# Altium schematic sheet Schematic.SchDoc(Schematic)
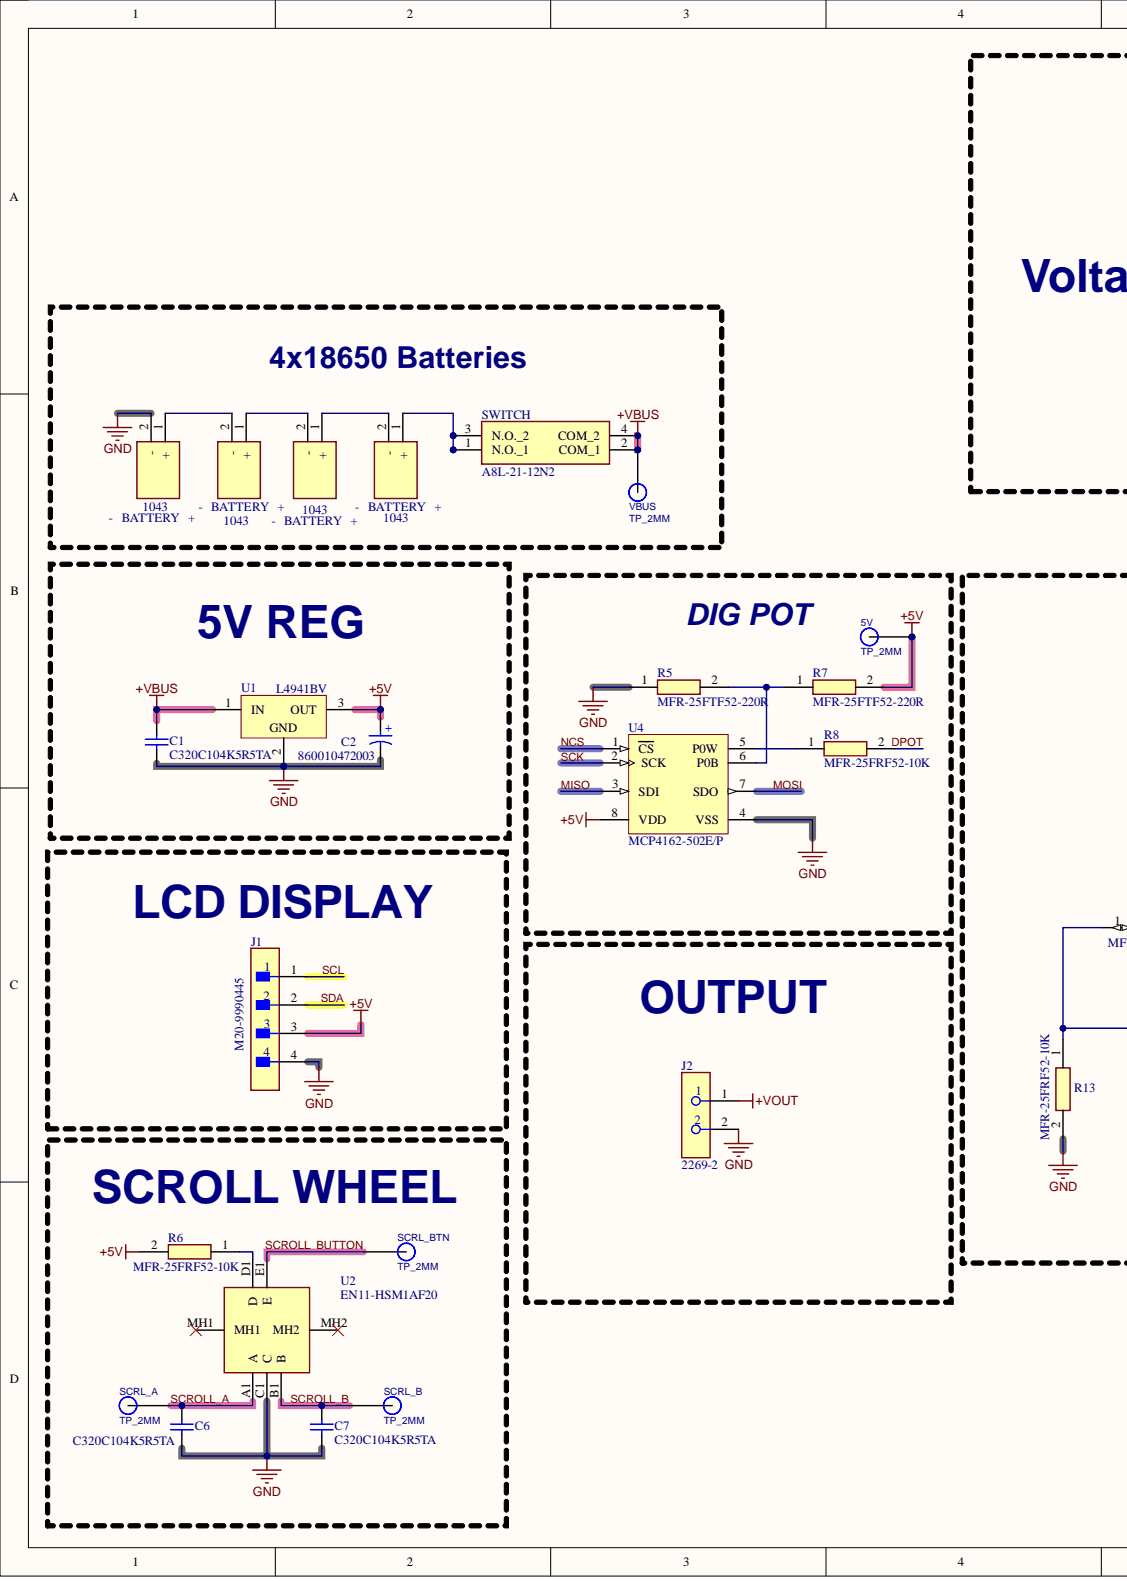
[diagram p0: Schematic.SchDoc, left]
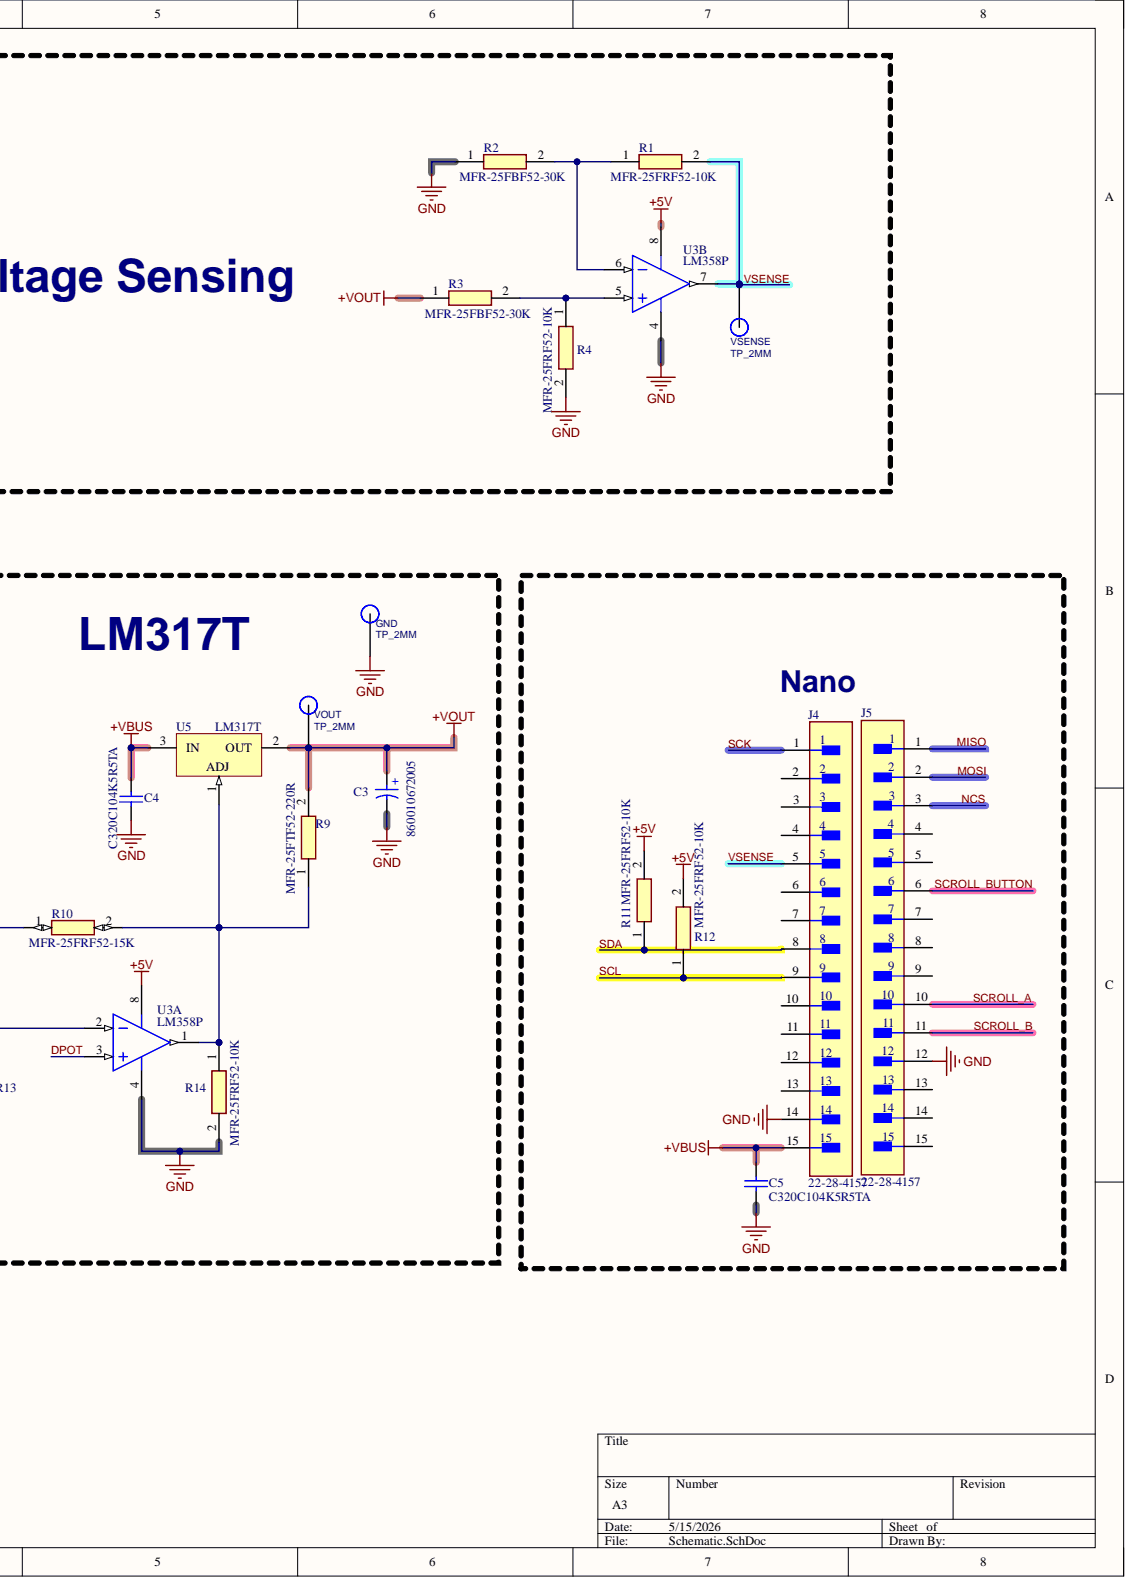
[diagram p1: Schematic.SchDoc, right]

## Parts
5V  TP_2MM
-_BATTERY_+  1043
-_BATTERY_+  1043
-_BATTERY_+  1043
-_BATTERY_+  1043
C1  C320C104K5R5TA
C2  860010472003
C3  860010672005
C4  C320C104K5R5TA
C5  C320C104K5R5TA
C6  C320C104K5R5TA
C7  C320C104K5R5TA
GND  TP_2MM
J1  M20-9990445
J2  2269-2
J4  22-28-4157
J5  22-28-4157
R1  MFR-25FRF52-10K
R2  MFR-25FBF52-30K
R3  MFR-25FBF52-30K
R4  MFR-25FRF52-10K
R5  MFR-25FTF52-220R
R6  MFR-25FRF52-10K
R7  MFR-25FTF52-220R
R8  MFR-25FRF52-10K
R9  MFR-25FTF52-220R
R10  MFR-25FRF52-15K
R11  MFR-25FRF52-10K
R12  MFR-25FRF52-10K
R13  MFR-25FRF52-10K
R14  MFR-25FRF52-10K
SCRL_A  TP_2MM
SCRL_B  TP_2MM
SCRL_BTN  TP_2MM
SWITCH  A8L-21-12N2
U1  L4941BV
U2  EN11-HSM1AF20
U3  LM358P  (parts A,B)
U4  MCP4162-502E/P
U5  LM317T
VBUS  TP_2MM
VOUT  TP_2MM
VSENSE  TP_2MM

## Nets
+5V: 5V.TP C2.1 J1.3 R6.2 R7.2 R11.2 R12.2 U1.3 U3.8 U4.8(VDD)
+VBUS: C1.2 C4.2 C5.2 J4.15 SWITCH.2(COM_1) SWITCH.4(COM_2) U1.1 U5.3 VBUS.TP
+VOUT: C3.1 J2.1 R3.1 R9.2 U5.2 VOUT.TP
GND: -_BATTERY_+.2 C1.1 C2.2 C3.2 C4.1 C5.1 C6.1 C7.1 GND.TP J1.4 J2.2 J4.14 J5.12 R2.1 R4.2 R5.1 R13.2 R14.2 U1.2 U2.C1(C) U3.4 U4.4(VSS)
DPOT: R8.2 U3.3
MISO: J5.1 U4.3(SDI)
MOSI: J5.2 U4.7(SDO)
NCS: J5.3 U4.1(CS)
SCK: J4.1 U4.2(SCK)
SCL: J1.1 J4.9 R12.1
SCROLL_A: C6.2 J5.10 SCRL_A.TP U2.A1(A)
SCROLL_B: C7.2 J5.11 SCRL_B.TP U2.B1(B)
SCROLL_BUTTON: J5.6 SCRL_BTN.TP U2.E1(E)
SDA: J1.2 J4.8 R11.1
VSENSE: J4.5 R1.2 U3.7 VSENSE.TP
Net-   BATTERY   +_1: -_BATTERY_+.1 -_BATTERY_+.2 SWITCH.1(N.O._1) SWITCH.3(N.O._2)
NetR1_1: R1.1 R2.2 U3.6
NetR3_2: R3.2 R4.1 U3.5
NetR5_2: R5.2 R7.1 U4.6(P0B)
NetR6_1: R6.1 U2.D1(D)
NetR8_1: R8.1 U4.5(P0W)
NetR9_1: R9.1 R10.2 R14.1 U3.1 U5.1
NetR10_1: R10.1 R13.1 U3.2

Not connected: J4 2 3 4 6 7 10 11 12 13; J5 4 5 7 8 9 13 14 15; U2 MH1 MH2

## Library data of the parts
-_BATTERY_+ -_BATTERY_+ -_BATTERY_+ -_BATTERY_+ = 1043: Undefined or Miscellaneous; Manufacturer_Name: Keystone Electronics; Arrow Part Number: 1043; Arrow Price/Stock: https://www.arrow.com/en/products/1043/keystone-electronics.html?utm_currency=USD&region=europe; Component Kind: Standard; Datasheet Link: http://www.keyelco.com/product-pdf.cfm?p=919; Manufacturer_Part_Number: 1043; Mouser Part Number: 534-1043; Mouser Price/Stock: https://www.mouser.co.uk/ProductDetail/Keystone-Electronics/1043?qs=%2F7TOpeL5Mz6j%2FnxeOA1rsg%3D%3D
C1 C4-C7 = CMP-1664-00004-1: Radial Leaded Multilayer Ceramic Capacitor, 0.1 uF, +/- 10%, 50 V, -55 to 125 degC, 2-Pin THD, RoHS, Tape and Reel; Case\Package: SOT-23-5; Dropout Voltage: 260 mV; Max Input Voltage: 8 V; Max Power Dissipation: 250 mW; Output Current: 150 mA; Output Voltage: 5 V; Outputs: 1; Component Kind: Standard; ComponentLink1Description: Manufacturer URL; ComponentLink1URL: http://www.kemet.com/; ComponentLink2Description: Datasheet; ComponentLink2URL: http://www.kemet.com/docfinder?Partnumber=C320C104K5R5TA; DatasheetVersion: Dec-15; Height: 1.3 mm; Length: 3 mm; Library Name: Altium Content Vault; Max Operating Temperature: 85 degC; Min Operating Temperature: -40 degC; Mount: Surface Mount; Package Quantity: 3000; PackageDescription: 2-Pin Through Hole Device, Body 5.08 x 3.18 mm, Pitch 2.54 mm; PackageReference: CAPR51-254-508X318X736; Packaging: Tape and Reel; Pins: 5; Radiation Hardening: No; RoHSCompliant: true; Width: 1.8 mm
C2 = CMP-207834-000017-3: WCAP-ATG8 Aluminum Electrolytic Capacitors; Capacitance: 22µF; Dissipation Factor: 14%; Leakage Current: 5.5µA; Life (Hours): 2000hours; Material: Aluminium; Pitch: 2mm; Polarity: Polar; Ripple Current: 94mA; Tolerance: 20%; Voltage Rating: 25V; Case/Package: Radial; Component Kind: Standard; Diameter: 5mm; Height: 12.5mm; Height - Seated (Max): 12.4968mm; Lead Pitch: 2.0066mm; Length: 11mm; Library Name: Altium Content Vault; Max Operating Temperature: 85°C; Min Operating Temperature: -40°C; Mount: Through Hole; Packaging: Tape & Box (TB); REACH SVHC: Unknown; RoHS: Compliant; Voltage Rating (DC): 25V
C3 = CMP-03913-54007258-2: Alum. Electrolytic Cap 1UF 50V 5MM Radial WCAP-ATG8 Series Lifetime 2000H +85OC; Capacitance: 1µF; Leakage Current: 3µA; Life (Hours): 2000hours; Material: Aluminium; Pitch: 2mm; Polarity: Polar; Ripple Current: 17mA; Tolerance: 20%; Case/Package: Radial; Component Kind: Standard; Diameter: 5mm; Height: 11mm; Height - Seated (Max): 12.4968mm; Lead Pitch: 2.0066mm; Length: 11mm; Library Name: Altium Content Vault; Max Operating Temperature: 85°C; Min Operating Temperature: -40°C; Mount: Through Hole; Packaging: Tape & Box (TB); REACH SVHC: Unknown; RoHS: Compliant; Voltage Rating: 50V; Voltage Rating (DC): 50V
J1 = CMP-00671-05399696-1: M20-9990445 Conn Unshrouded Header HDR 4 POS 2.54mm Solder ST Thru-Hole Bulk/Loose; Connector Type: Male Pin; Contact Resistance: 25mΩ; Current Rating: 3A; Gender: Male; Insulation Resistance: 10GΩ; Number of Contacts: 4; Voltage Rating (AC): 750V; Case/Package: SIL; Color: Black; Component Kind: Standard; Contact Material: Brass, Bronze, Gold, Nickel; Contact Mating Length: 6.096mm; Contact Plating: Gold; Depth: 2.54mm; Height: 2.54mm; Housing Color: Black; Housing Material: Nylon; Lead Pitch: 2.54mm; Length: 10.16mm; Library Name: Altium Content Vault; Max Current Rating: 3A; Max Operating Temperature: 105°C; Min Operating Temperature: -40°C; Mount: Through Hole; NexarPartId: 5399696; Number of Pins: 4; Number of Positions: 4; Number of Rows: 1; Orientation: Straight; Pitch: 2.54mm; Radiation Hardening: No; REACH SVHC: No SVHC; RoHS: Compliant; Termination: Solder; Voltage Rating (DC): 750V; Weight: 45.359237g; Width: 12.7mm
J2 = CMP-73906-000010-1: CONN DBL BANANA JACK TURRET; Body Material: PC; Current Rating: 15A; Gender: Female; Insulation: Mating End Insulated; Number of Contacts: 2; Voltage Rating: 2.5kV; Voltage Rating (DC): 70V; Color: Red; Component Kind: Standard; Contact Material: Brass; Contact Plating: Tin; Depth: 16mm; Height: 0.375inch; Housing Color: Red; Housing Material: Polycarbonate; Insulation Material: Polycarbonate; Lead Free: Lead Free; Length: 24inch; Library Name: Altium Content Vault; Max Current Rating: 15A; Mount: Panel; Number of Ports: 2; Orientation: Straight; Package Quantity: 5; Packaging: Bulk; REACH SVHC: No SVHC; RoHS Compliant: Yes; Termination: Solder; Weight: 0.033lb; Width: 4inch
J4 J5 = CMP-04776-000945-1: KK 100 HDR ASSY BKWY 15 CKT 30 S; Connector Type: Male Pin; Contact Resistance: 20mR; Current Rating: 4A; Gender: Male; Glow Wire Compliant: Non-compliant; Max Voltage Rating (DC): 250.25kV; Number of Circuits: 15; Pitch: 2.54mm; Voltage Rating: 250V; Color: Black; Component Kind: Standard; Contact Material: Brass; Contact Mating Length: 0.32inch; Contact Plating: Gold; Contact Type: Male Pin; Housing Color: Black; Housing Material: Thermoplastic; Lead Free: Lead Free; Library Name: Altium Content Vault; Max Operating Temperature: 75°C; Min Operating Temperature: 0°C; Mount: Through Hole; Number of Contacts: 15; Number of Positions: 15; Number of Rows: 1; Orientation: Straight; Package Quantity: 1; Packaging: Bulk; Series: KK® 42375; Termination: Solder
R1 R4 R6 R8 R11-R14 = MFR-25FRF52-10K: Resistor; Manufacturer_Name: Yageo Group; Arrow Part Number: MFR-25FRF52-10K; Arrow Price/Stock: https://www.arrow.com/en/products/mfr-25frf52-10k/yageo; Component Kind: Standard; Datasheet Link: https://mentor.componentsearchengine.com/Datasheets/1/MFR-25FRF52-10K.pdf; Manufacturer_Part_Number: MFR-25FRF52-10K; Mouser Part Number: 603-MFR-25FRF5210K; Mouser Price/Stock: https://www.mouser.co.uk/ProductDetail/YAGEO/MFR-25FRF52-10K?qs=5furm%2FeQHzSFNehIjUjbug%3D%3D
R2 R3 = MFR-25FBF52-30K: Resistor; Manufacturer_Name: Yageo Group; Component Kind: Standard; Datasheet Link: https://www.mouser.com/datasheet/2/447/YAGEO_MFR_datasheet_2023v3-3324391.pdf; Manufacturer_Part_Number: MFR-25FBF52-30K
R5 R7 R9 = MFR-25FTF52-220R: Resistor; Manufacturer_Name: Yageo Group; Component Kind: Standard; Datasheet Link: https://www.mouser.de/datasheet/2/447/YAGEO_MFR_datasheet_2023v3-3324391.pdf; Manufacturer_Part_Number: MFR-25FTF52-220R
R10 = MFR-25FRF52-15K: Resistor; Manufacturer_Name: Yageo Group; Arrow Part Number: MFR-25FRF52-15K; Arrow Price/Stock: https://www.arrow.com/en/products/mfr-25frf52-15k/yageo; Component Kind: Standard; Datasheet Link: https://nz.mouser.com/datasheet/2/447/Yageo_LR_MFR_1-1714151.pdf; Manufacturer_Part_Number: MFR-25FRF52-15K; Mouser Part Number: 603-MFR-25FRF5215K; Mouser Price/Stock: https://www.mouser.co.uk/ProductDetail/Yageo/MFR-25FRF52-15K?qs=5furm%2FeQHzTYkY1Zl33S4g%3D%3D
SWITCH = A8L-21-12N2: Switch; Manufacturer_Name: OMRON Electronics; Arrow Part Number: A8L-21-12N2; Arrow Price/Stock: https://www.arrow.com/en/products/a8l-21-12n2/omron?utm_currency=USD&region=nac; Component Kind: Standard; Datasheet Link: https://components.omron.com/us-en/sites/components.omron.com.us/files/datasheet_pdf/A114-E1.pdf; Height: 22.1mm; Library Name: Schematic Library.SchLib; Manufacturer_Part_Number: A8L-21-12N2; Mouser Part Number: 653-A8L-21-12N2; Mouser Price/Stock: https://www.mouser.co.uk/ProductDetail/Omron-Electronics/A8L-21-12N2?qs=wE98CiVmOw76dmZWfHCJ3A%3D%3D
U1 = CMP-0244-00423-1: 1A Very Low Drop Regulator, 3-Pin TO-220; Component Kind: Standard; ComponentLink1Description: Manufacturer URL; ComponentLink1URL: http://www.st.com; ComponentLink2Description: Datasheet; ComponentLink2URL: http://www.st.com/internet/com/TECHNICAL_RESOURCES/TECHNICAL_LITERATURE/DATASHEET/CD00000443.pdf; ComponentLink3Description: Package Specification; ComponentLink3URL: http://www.st.com/internet/com/TECHNICAL_RESOURCES/TECHNICAL_LITERATURE/PACKAGE_INFORMATION/CD00004649.pdf; DatasheetVersion: 15/09/2006; Library Name: Altium Content Vault; PackageDescription: TO, Thru-Hole, Vertical, Heatsink Mounted; 3 In-Line Leads; Pitch 2.54 mm; PackageReference: TO220; PackageVersion: ver3.0
U2 = EN11-HSM1AF20: Undefined or Miscellaneous; Manufacturer_Name: TT Electronics; Component Kind: Standard; Datasheet Link: https://datasheet.datasheetarchive.com/originals/distributors/Datasheets_SAMA/2e239bccdca13b9604c0057335e160d7.pdf; Height: 27.1mm; Manufacturer_Part_Number: EN11-HSM1AF20; Mouser Part Number: 858-EN11-HSM1AF20; Mouser Price/Stock: https://www.mouser.co.uk/ProductDetail/BI-Technologies-TT-Electronics/EN11-HSM1AF20?qs=%252BUYXD5bnyXqPYOUPwiF4VA%3D%3D
U3 = CMP-2000-06549-1: Dual Operational Amplifier, 3 to 32 V, 0 to 70 degC, 8-Pin PDIP (P), RoHS, Tape and Reel; Manufacturer: Technik Industrial; Component Kind: Standard; Datasheet URL: http://www.ti.com/lit/ds/symlink/lm358.pdf; Datasheet Version: Rev. T, 04/2015; Library Name: Altium Content Vault; Manufacturer URL: http://www.technik.com.hk/; Mounting Technology: ThroughHole; Package Description: 8-Pin Double In-line Package, Body 9.59 x 6.35 mm, Pitch 2.54 mm; Package Reference: P8; Package URL: http://www.ti.com/lit/ml/mpdi001b/mpdi001b.pdf
U4 = CMP-0188-00189-2: 7/8-Bit Single/Dual SPI Digital POT with Non-Volatile Memory, 8-Pin PDIP, Extended Temperature; Code_JEDEC: MS-001; Component Kind: Standard; ComponentLink1Description: Manufacturer URL; ComponentLink1URL: http://www.microchip.com/; ComponentLink2Description: Datasheet; ComponentLink2URL: http://ww1.microchip.com/downloads/en/DeviceDoc/22059b.pdf; ComponentLink3Description: Package Specification; ComponentLink3URL: http://www.microchip.com/stellent/groups/techpub_sg/documents/packagingspec/en012702.pdf; DatasheetVersion: revB, Dec-2008; Library Name: Altium Content Vault; PackageDescription: 8-Lead Plastic Dual In-Line (P) - 300 mil Body [PDIP]; PackageReference: PDIP300-P8; PackageVersion: revBB, Aug-2009; RevisionNote: URLs added.
U5 = CMP-0244-00444-1: 1.5A, 1.2V to 37V Adjustable Voltage Regulator, 3-Pin TO-220; Component Kind: Standard; ComponentLink1Description: Manufacturer URL; ComponentLink1URL: http://www.st.com; ComponentLink2Description: Datasheet; ComponentLink2URL: http://www.st.com/internet/com/TECHNICAL_RESOURCES/TECHNICAL_LITERATURE/DATASHEET/CD00000455.pdf; ComponentLink3Description: Package Specification; ComponentLink3URL: http://www.st.com/internet/com/TECHNICAL_RESOURCES/TECHNICAL_LITERATURE/PACKAGE_INFORMATION/CD00004649.pdf; DatasheetVersion: 1/11/2011; Library Name: Altium Content Vault; PackageDescription: TO, Thru-Hole, Vertical, Heatsink Mounted; 3 In-Line Leads; Pitch 2.54 mm; PackageReference: TO-220; PackageVersion: 2011
5V GND SCRL_A SCRL_B SCRL_BTN VBUS VOUT VSENSE = TP_2MM: Component Kind: Standard; Library Name: C2S.SchLib
